# Altium schematic sheet EMF Measurement rev5.SchDoc
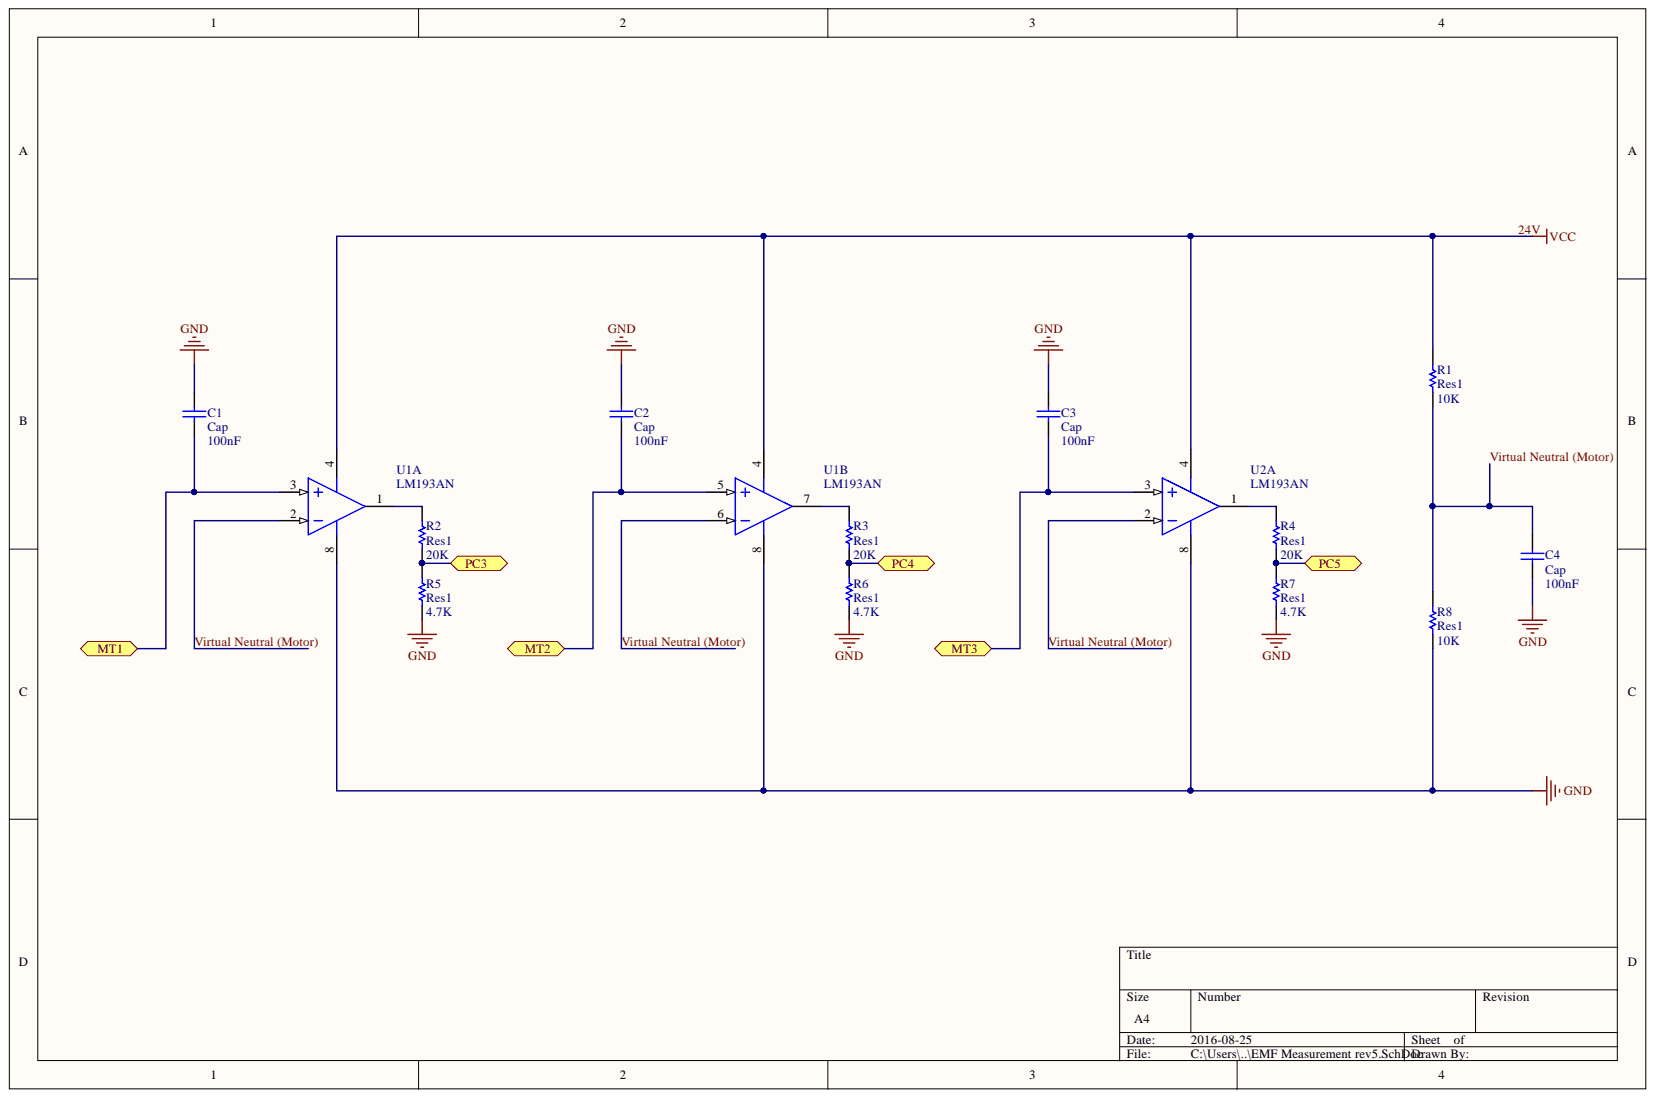
[diagram p0: EMF Measurement rev5.SchDoc]

## Parts
C1  Cap  value 100nF  [RAD-0.3]
C2  Cap  value 100nF  [RAD-0.3]
C3  Cap  value 100nF  [RAD-0.3]
C4  Cap  value 100nF  [RAD-0.3]
R1  Res1  value 10K  [AXIAL-0.3]
R2  Res1  value 20K  [AXIAL-0.3]
R3  Res1  value 20K  [AXIAL-0.3]
R4  Res1  value 20K  [AXIAL-0.3]
R5  Res1  value 4.7K  [AXIAL-0.3]
R6  Res1  value 4.7K  [AXIAL-0.3]
R7  Res1  value 4.7K  [AXIAL-0.3]
R8  Res1  value 10K  [AXIAL-0.3]
U1  LM193AN  [DIP8]  (parts A,B)
U2  LM193AN  [DIP8]  (part A)

## Ports
MT1, MT2, MT3, PC3, PC4, PC5

## Nets
GND: C1.2 C2.2 C3.2 C4.1 R5.1 R6.1 R7.1 R8.1 U1.8 U2.8
24V: R1.2 U1.4 U2.4
MT1: C1.1 U1.3 ; port MT1
MT2: C2.1 U1.5 ; port MT2
MT3: C3.1 U2.3 ; port MT3
PC3: R2.1 R5.2 ; port PC3
PC4: R3.1 R6.2 ; port PC4
PC5: R4.1 R7.2 ; port PC5
VIRTUAL NEUTRAL (MOTOR): C4.2 R1.1 R8.2 U1.2 U1.6 U2.2
NetR2_2: R2.2 U1.1
NetR3_2: R3.2 U1.7
NetR4_2: R4.2 U2.1

## Library data of the parts
C1-C4 = Cap: Capacitor; Component Kind: Standard; LatestRevisionDate: 17-Jul-2002; LatestRevisionNote: Re-released for DXP Platform.; Library Name: Miscellaneous Devices.IntLib; PackageDescription: Radial Cap, Thru-Hole; 2 Leads; 0.3 in Pin Spacing; PackageReference: RAD-0.3; Published: 8-Jun-2000; Signal Integrity: Cap; Simulation: CAP; Publisher: Altium Limited
R1-R8 = Res1: Resistor; Component Kind: Standard; LatestRevisionDate: 17-Jul-2002; LatestRevisionNote: Re-released for DXP Platform.; Library Name: Miscellaneous Devices.IntLib; PackageDescription: Axial Device, Thru-Hole; 2 Leads; 0.3 in Pin Spacing; PackageReference: AXIAL-0.3; Published: 8-Jun-2000; Signal Integrity: Res; Simulation: RESISTOR; Publisher: Altium Limited
U1 U2 = LM193AN: Low-Power Dual Voltage Comparator; Code_JEDEC: MO-001-AN; Component Kind: Standard; ComponentLink1Description: Manufacturer Link; ComponentLink1URL: http://www.st.com/; ComponentLink2Description: Datasheet; ComponentLink2URL: http://www.st.com/stonline/products/literature/ds/2164/lm193.pdf; DatasheetVersion: Nov-1992; LatestRevisionDate: 06-Jun-2005; LatestRevisionNote: Stylized 3D Model Added.; Library Name: ST Analog Comparator.IntLib; PackageDescription: DIP; 8 Leads; Row Spacing 7.62 mm; Pitch 2.54 mm; PackageReference: DIP8; PCB3D: DIP8; Published: 24-Aug-2001; Publisher: Altium Limited
